# Altium schematic sheet MotorDriver.SchDoc
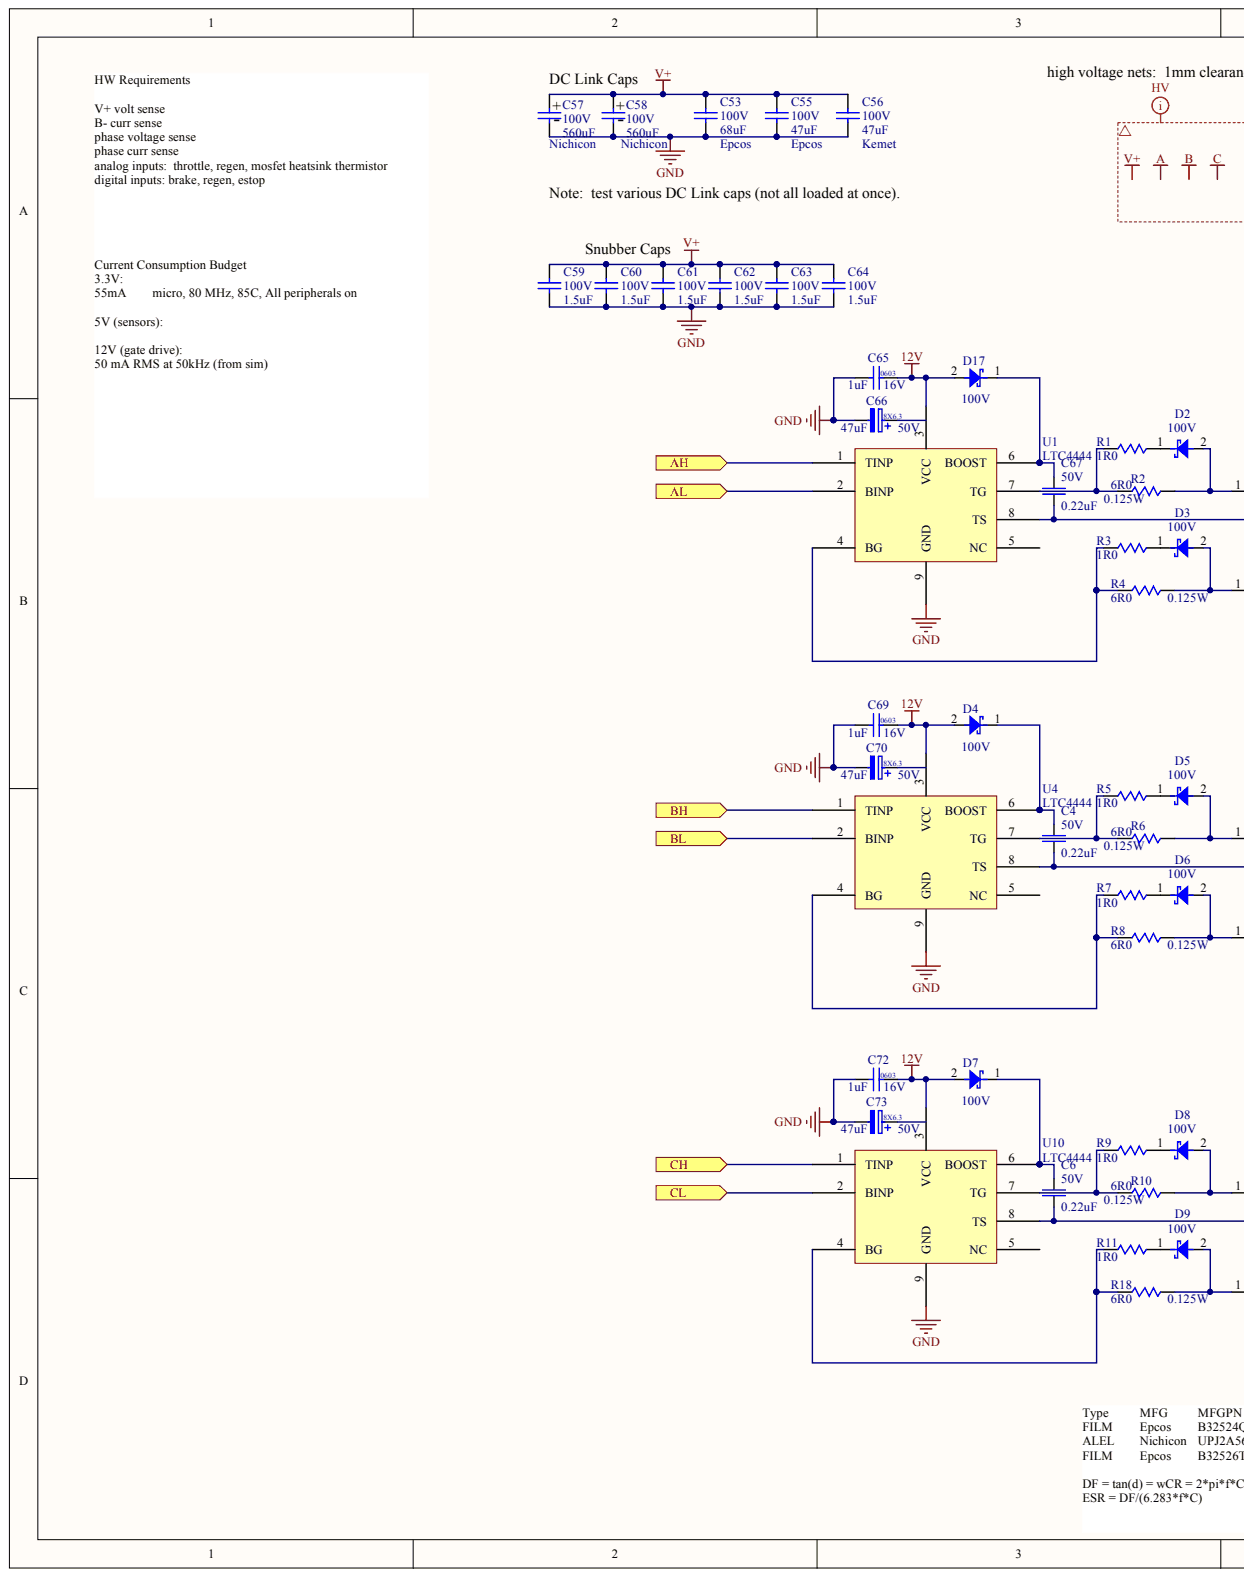
[diagram p0: MotorDriver.SchDoc, left]
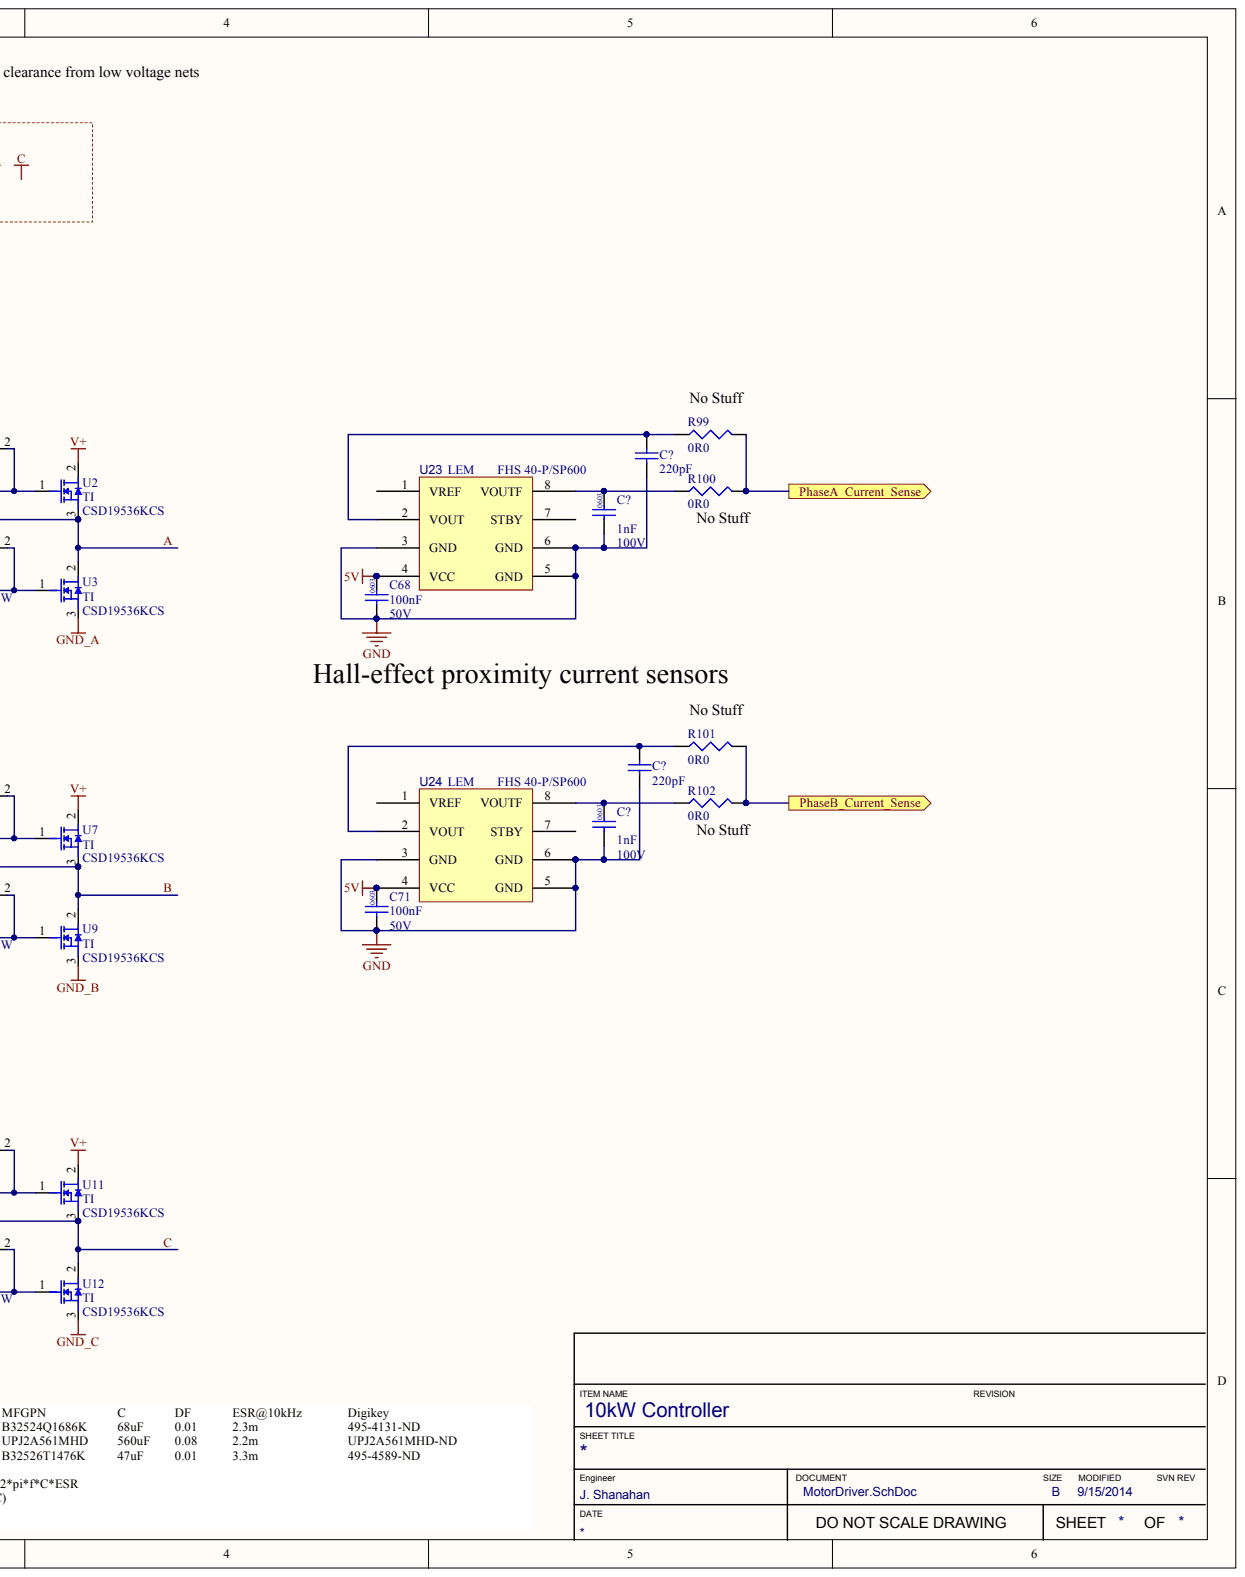
[diagram p1: MotorDriver.SchDoc, right]

## Parts
C4  value 0.22uF  voltage: 50V  (hidden comment: 0.22UF,25V,X7R(0603))
C6  value 0.22uF  voltage: 50V  (hidden comment: 0.22UF,25V,X7R(0603))
C53  value 68uF  MFG1: Epcos  voltage: 100V  (hidden comment: 68uF,100V,Film)
C55  value 47uF  MFG1: Epcos  voltage: 100V  (hidden comment: 47uF,100V,Film,Epcos)
C56  value 47uF  MFG1: Kemet  voltage: 100V  (hidden comment: 47uF,100V,Film,Kemet)
C57  value 560uF  MFG1: Nichicon  voltage: 100V  (hidden comment: 560uF,100V,ALEL)
C58  value 560uF  MFG1: Nichicon  voltage: 100V  (hidden comment: 560uF,100V,ALEL)
C59  value 1.5uF  voltage: 100V  (hidden comment: 1.5uF,100V,Ceramic)
C60  value 1.5uF  voltage: 100V  (hidden comment: 1.5uF,100V,Ceramic)
C61  value 1.5uF  voltage: 100V  (hidden comment: 1.5uF,100V,Ceramic)
C62  value 1.5uF  voltage: 100V  (hidden comment: 1.5uF,100V,Ceramic)
C63  value 1.5uF  voltage: 100V  (hidden comment: 1.5uF,100V,Ceramic)
C64  value 1.5uF  voltage: 100V  (hidden comment: 1.5uF,100V,Ceramic)
C65  voltage: 16V  (hidden comment: 1uF)
C66  47uF  voltage: 50V
C67  value 0.22uF  voltage: 50V  (hidden comment: 0.22UF,25V,X7R(0603))
C68  value 100nF  voltage: 50V  (hidden comment: 2005864)
C69  voltage: 16V  (hidden comment: 1uF)
C70  47uF  voltage: 50V
C71  value 100nF  voltage: 50V  (hidden comment: 2005864)
C72  voltage: 16V  (hidden comment: 1uF)
C73  47uF  voltage: 50V
C?  value 220pF  (hidden comment: 220PF,50V,X7R(0603))
C?  value 220pF  (hidden comment: 220PF,50V,X7R(0603))
C?  Voltage: 100V  (hidden comment: 1nF)
C?  Voltage: 100V  (hidden comment: 1nF)
D2  voltage: 100V  (hidden comment: BAT46W(SOD-123))
D3  voltage: 100V  (hidden comment: BAT46W(SOD-123))
D4  BAT46W(SOD-123)  (value not drawn)
D5  voltage: 100V  (hidden comment: BAT46W(SOD-123))
D6  voltage: 100V  (hidden comment: BAT46W(SOD-123))
D7  voltage: 100V  (hidden comment: BAT46W(SOD-123))
D8  voltage: 100V  (hidden comment: BAT46W(SOD-123))
D9  voltage: 100V  (hidden comment: BAT46W(SOD-123))
D17  voltage: 100V  (hidden comment: BAT46W(SOD-123))
R1  value 1R0  (hidden comment: 1R0,0.125W,1%(0805))
R2  value 6R0  POWER: 0.125W  (hidden comment: 6R0,0.125W,1%(0805))
R3  value 1R0  (hidden comment: 1R0,0.125W,1%(0805))
R4  value 6R0  POWER: 0.125W  (hidden comment: 6R0,0.125W,1%(0805))
R5  value 1R0  (hidden comment: 1R0,0.125W,1%(0805))
R6  value 6R0  POWER: 0.125W  (hidden comment: 6R0,0.125W,1%(0805))
R7  value 1R0  (hidden comment: 1R0,0.125W,1%(0805))
R8  value 6R0  POWER: 0.125W  (hidden comment: 6R0,0.125W,1%(0805))
R9  value 1R0  (hidden comment: 1R0,0.125W,1%(0805))
R10  POWER: 0.125W  (hidden comment: 6R0,0.125W,1%(0805))
R11  value 1R0  (hidden comment: 1R0,0.125W,1%(0805))
R18  value 6R0  POWER: 0.125W  (hidden comment: 6R0,0.125W,1%(0805))
R99  value 0R0  (hidden comment: 0R0,0.10W,JMP(0603))
R100  value 0R0  (hidden comment: 0R0,0.10W,JMP(0603))
R101  value 0R0  (hidden comment: 0R0,0.10W,JMP(0603))
R102  value 0R0  (hidden comment: 0R0,0.10W,JMP(0603))
U1  LTC4444
U2  MFG1: TI  MFG1_PN: CSD19536KCS  (hidden comment: 1029149-00-A)
U3  MFG1: TI  MFG1_PN: CSD19536KCS  (hidden comment: 1029149-00-A)
U4  LTC4444
U7  MFG1: TI  MFG1_PN: CSD19536KCS  (hidden comment: 1029149-00-A)
U9  MFG1: TI  MFG1_PN: CSD19536KCS  (hidden comment: 1029149-00-A)
U10  LTC4444
U11  MFG1: TI  MFG1_PN: CSD19536KCS  (hidden comment: 1029149-00-A)
U12  MFG1: TI  MFG1_PN: CSD19536KCS  (hidden comment: 1029149-00-A)
U23  LEM FHS 40-P/SP600  MFG1: LEM  MFG1_PN: FHS 40-P/SP600
U24  LEM FHS 40-P/SP600  MFG1: LEM  MFG1_PN: FHS 40-P/SP600

## Ports
AH, AL, BH, BL, CH, CL, PHASEA_CURRENT_SENSE, PHASEB_CURRENT_SENSE

## Nets
5V: C68.1 C71.1 U23.4(VCC) U24.4(VCC)
12V: C65.1 C69.1 C72.1 D4.2 D7.2 D17.2 U1.3(VCC) U4.3(VCC) U10.3(VCC)
GND: C53.2 C55.2 C56.2 C57.2 C58.2 C59.2 C60.2 C61.2 C62.2 C63.2 C64.2 C65.2 C66.2 C68.2 C69.2 C70.2 C71.2 C72.2 C73.2 C?.1 C?.2 U1.9(GND) U4.9(GND) U10.9(GND) U23.3(GND) U23.5(GND) U23.6(GND) U24.3(GND) U24.5(GND) U24.6(GND)
GND_A: U3.3
GND_B: U9.3
GND_C: U12.3
V+: C53.1 C55.1 C56.1 C57.1 C58.1 C59.1 C60.1 C61.1 C62.1 C63.1 C64.1 U2.2 U7.2 U11.2
A: C67.1 U1.8(TS) U2.3 U3.2
AH: U1.1(TINP) ; port AH
AL: U1.2(BINP) ; port AL
B: C4.1 U4.8(TS) U7.3 U9.2
BH: U4.1(TINP) ; port BH
BL: U4.2(BINP) ; port BL
C: C6.1 U10.8(TS) U11.3 U12.2
CH: U10.1(TINP) ; port CH
CL: U10.2(BINP) ; port CL
NetC4_2: C4.2 D4.1 U4.6(BOOST)
NetC6_2: C6.2 D7.1 U10.6(BOOST)
NetC67_2: C67.2 D17.1 U1.6(BOOST)
NetC?_1: C?.1 R100.1 R102.1 U23.8(VOUTF) U24.8(VOUTF)
NetC?_2: C?.2 R99.1 R101.1 U23.2(VOUT) U24.2(VOUT)
NetD2_1: D2.1 R1.2
NetD2_2: D2.2 R2.2 U2.1
NetD3_1: D3.1 R3.2
NetD3_2: D3.2 R4.2 U3.1
NetD5_1: D5.1 R5.2
NetD5_2: D5.2 R6.2 U7.1
NetD6_1: D6.1 R7.2
NetD6_2: D6.2 R8.2 U9.1
NetD8_1: D8.1 R9.2
NetD8_2: D8.2 R10.2 U11.1
NetD9_1: D9.1 R11.2
NetD9_2: D9.2 R18.2 U12.1
NetR1_1: R1.1 R2.1 U1.7(TG)
NetR3_1: R3.1 R4.1 U1.4(BG)
NetR5_1: R5.1 R6.1 U4.7(TG)
NetR7_1: R7.1 R8.1 U4.4(BG)
NetR9_1: R9.1 R10.1 U10.7(TG)
NetR11_1: R11.1 R18.1 U10.4(BG)
NetR99_2: R99.2 R100.2 ; port PHASEA_CURRENT_SENSE
NetR101_2: R101.2 R102.2 ; port PHASEB_CURRENT_SENSE
N00190:

Not connected: C66 1; C70 1; C73 1; U1 5(NC); U4 5(NC); U10 5(NC); U23 1(VREF) 7(STBY); U24 1(VREF) 7(STBY)

## Library data of the parts
C4 C6 C67 = (no symbol name): MFG1_PN: GCM188R71E224KA55D; MFG1: Murata
C53 = (no symbol name): MFG1_PN: B32524Q1686K; Revision: July-2002: Re-released for DXP Platform.
C55 = (no symbol name): MFG1_PN: B32526T1476K; Revision: July-2002: Re-released for DXP Platform.; Unit Price: 12.83
C56 = (no symbol name): MFG1_PN: SNEJ5470MB6(2)0; DF: 0.01; Revision: July-2002: Re-released for DXP Platform.; Unit Price: ??.??
C57 C58 = (no symbol name): MFG1_PN: UPJ2A561MHD; Revision: July-2002: Re-released for DXP Platform.
C59-C64 = (no symbol name): MFG1: AVX; MFG1_PN: 12101C155KAT2A; Revision: July-2002: Re-released for DXP Platform.; Unit Price: .31
C65 C69 C72 = (no symbol name): MFG1_PN: GCM188R71C105KA64D; MFG1: Murata; Load: NL; Detail Description: 1uF Capacitor, 16V, X7R, 10%, in 0603 package, Automotive Grade.; Value: 1uF
C66 C70 C73 = (no symbol name): tolerance: 20%; LEVEL: 1; MFG1_PN: UCD1H470MCL6GS; MFG1: Nichicon; Load: NL; SAP: 1019592-00-A; Automotive: AEC-Q; RoHS: YES; Value: 47uF
C68 C71 = (no symbol name): tolerance: 10%; MFG1_PN: GCM188R71H104KA57D; MFG1: Murata; Load: NL; Detail Description: 100nF Capacitor, 50V, X7R, 10%, in 0603 package, Automotive Grade.; TPN: 01-006841-00; Enovia: 2005864; SAP: 2005864
C? C? = (no symbol name): tolerance: 10%; TPN: 01-002668-00; LEVEL: 1; MFG1: MURATA; MFG1_PN: GRM188R71H221KA01D; MFG2_PN: C1608X7R1H221KT; SAP: 2001046; Revision: July-2002: Re-released for DXP Platform.; voltage: 50V; RoHS: YES; TYPE: X7R; Supplier 1: Digi-Key; Supplier 2: Digi-Key; MFG2: TDK; Supplier 3: Digi-Key; Supplier 4: Digi-Key; Supplier Part Number 1: 490-1483-6-ND; Supplier Part Number 2: 490-1483-2-ND; Supplier Part Number 3: 445-1306-6-ND; Supplier Part Number 4: 445-1306-2-ND
C? C? = (no symbol name): MFG1_PN: GCM188R72A102KA37D; MFG1: Murata; Value: 1nF
D2 D3 D5-D9 D17 = (no symbol name): MFG1: Vishay; Load: NL; SAP: 1011467-00-A; MFG1_PN: BAT46W-V-GS08
D4 = (no symbol name): MFG1: Vishay; Load: NL; SAP: 1011467-00-A; MFG1_PN: BAT46W-V-GS08; voltage: 100V
R1 R3 R5 R7 R9 R11 = (no symbol name): MFG1_PN: CRCW08051R00JNEA; MFG1: Vishay; Revision: July-2002: Re-released for DXP Platform.; Tolerance: 1%; POWER: 0.125W; Supplier 1: Digi-Key; Supplier Part Number 1: 541-1.0ACT-ND
R2 R4 R6 R8 R10 R18 = (no symbol name): MFG1_PN: CRCW08056R04FKEA; MFG1: Vishay; Revision: July-2002: Re-released for DXP Platform.; Tolerance: 1%; Supplier 1: Digi-Key; Supplier Part Number 1: 541-6.04CCCT-ND
  R10: Value: 6R0
R99-R102 = (no symbol name): Tolerance: 1%; LEVEL: 1; MFG1_PN: CRCW06030000Z0EA; MFG1: VISHAY; Load: NL; TPN: 01-009258-00; SAP: 2008023; Revision: July-2002: Re-released for DXP Platform.; RoHS: YES; POWER: 0.10W; Automotive: YES
U1 U4 U10 = (no symbol name): MFGPN: LTC4444EMS8E#PBF; MFG: LTC; Unit Price: 3.4
